# Altium schematic sheet 13.RPI.SchDoc(13.RPI)
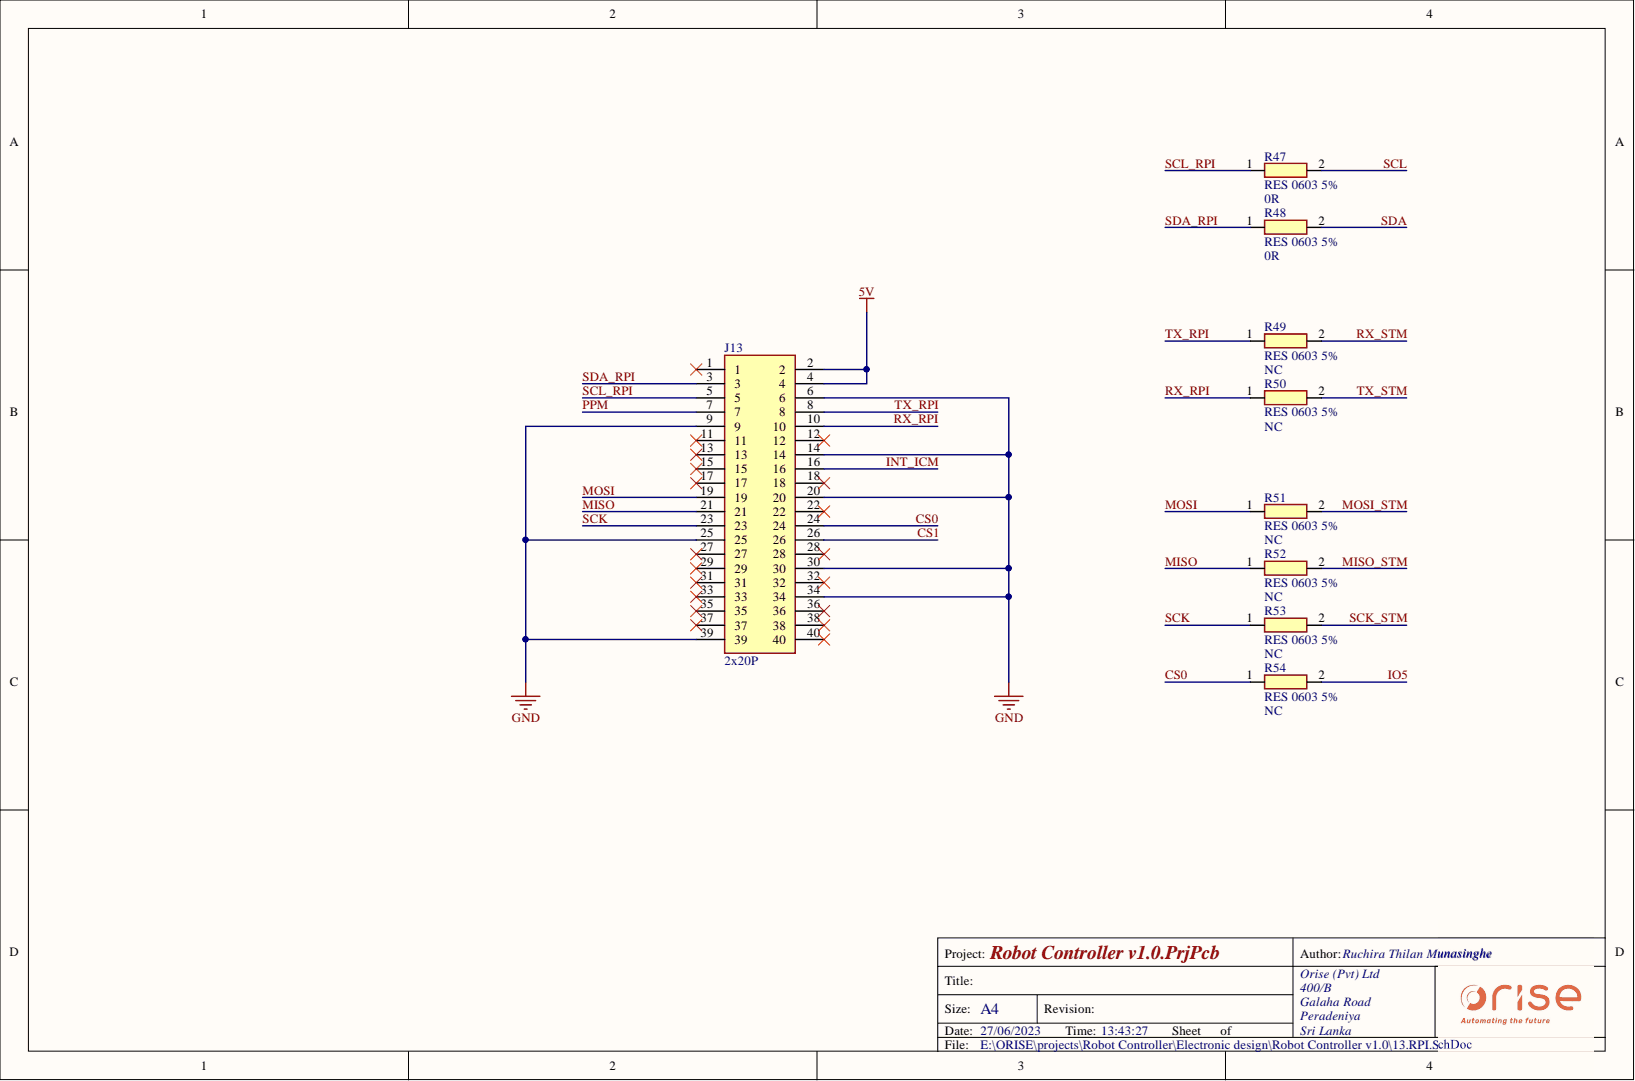
[diagram p0: 13.RPI.SchDoc]

## Parts
J13  2x20P  [*TE_2-534236-0]
R47  RES 0603 5%  value 0R  [RES 0603]
R48  RES 0603 5%  value 0R  [RES 0603]
R49  RES 0603 5%  [RES 0603 NC]
R50  RES 0603 5%  value NC  [RES 0603 NC]
R51  RES 0603 5%  value NC  [RES 0603 NC]
R52  RES 0603 5%  value NC  [RES 0603 NC]
R53  RES 0603 5%  value NC  [RES 0603 NC]
R54  RES 0603 5%  value NC  [RES 0603 NC]

## Nets
5V: J13.2 J13.4
GND: J13.6 J13.9 J13.14 J13.20 J13.25 J13.30 J13.34 J13.39
CS0: J13.24 R54.1
CS1: J13.26
INT_ICM: J13.16
IO5: R54.2
MISO: J13.21 R52.1
MISO_STM: R52.2
MOSI: J13.19 R51.1
MOSI_STM: R51.2
PPM: J13.7
RX_RPI: J13.10 R50.1
RX_STM: R49.2
SCK: J13.23 R53.1
SCK_STM: R53.2
SCL: R47.2
SCL_RPI: J13.5 R47.1
SDA: R48.2
SDA_RPI: J13.3 R48.1
TX_RPI: J13.8 R49.1
TX_STM: R50.2

Not connected: J13 1 11 12 13 15 17 18 22 27 28 29 31 32 33 35 36 37 38 40

## Library data of the parts
J13 = 40Pos Connector: 2x20P  Female Pitch 2.54mm; Library Name: Robot Controller v1.0.SchLib; Component Kind: Standard
R47 = RES 0603 5%: value: 0R; Library Name: Robot Controller v1.0.SchLib; Description: RES 0603 5%; Component Kind: Standard
R49 R51 = RES 0603 5% NC: value: NC; Library Name: Robot Controller v1.0.SchLib; Description: RES 0603 5%; Component Kind: Standard
R50 R52-R54 = RES 0603 5% NC: Library Name: Robot Controller v1.0.SchLib; Description: RES 0603 5%; Component Kind: Standard
R48 = RES 0603 5%: Library Name: Robot Controller v1.0.SchLib; Description: RES 0603 5%; Component Kind: Standard
